# Altium schematic sheet [12] MISC.SchDoc("[12] MISC")
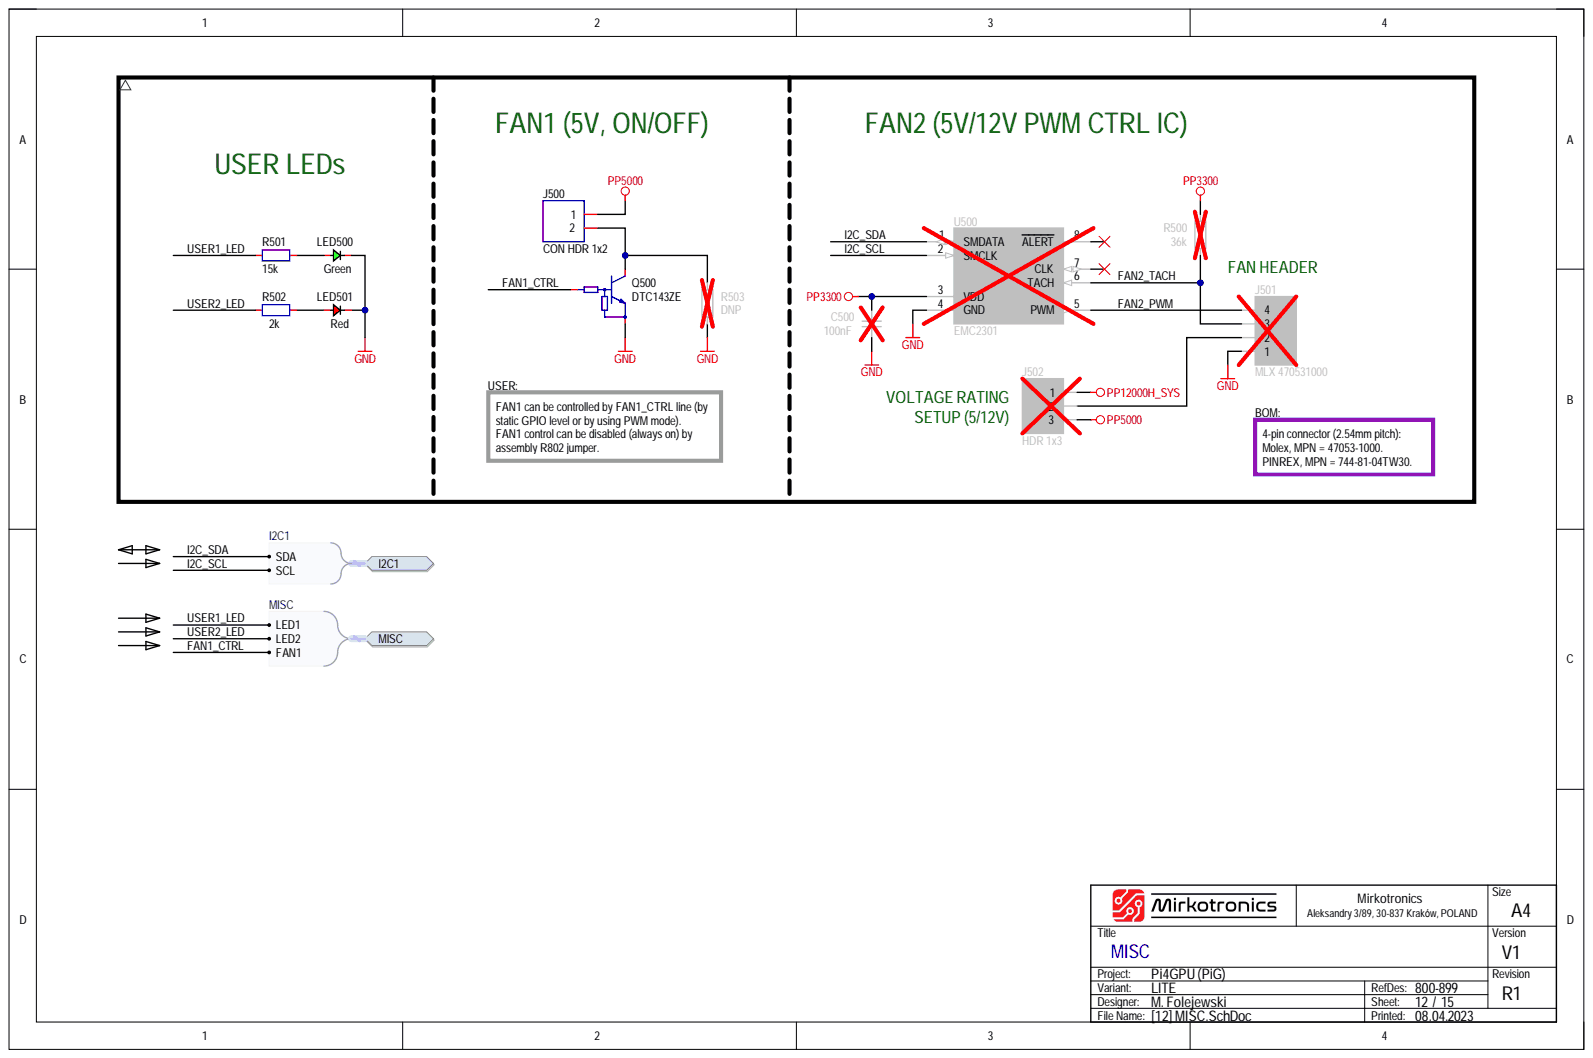
[diagram p0: [12] MISC.SchDoc]

## Parts
C500  100nF  [CAP_0603_R]
J500  CON HDR 1x2  [CON_HDR_P254_1X02_STR]
J501  MLX 470531000  MPN: 470531000  [CON_MLX_470531000]
J502  HDR 1x3  [CON_HDR_P254_1X03_STR_JMP]
LED500  Green  [LED_0805_GRN_R]
LED501  Red  [LED_0805_RED_R]
Q500  DTC143ZE  [PKG_SOT523_SC75_SOT416_P100_R]
R500  36k  [RES_0603_R]
R501  15k  [RES_0603_R]
R502  2k  [RES_0603_R]
R503  DNP  [RES_0603_R]
U500  EMC2301  [ICC_TSSOP8_300X300X110P65]

## Ports
I2C1, I2C1.SCL, I2C1.SDA, MISC, MISC.FAN1, MISC.LED1, MISC.LED2

## Nets
GND: C500.1 J501.1 LED500.1 LED501.1 Q500.2 R503.1 U500.4(GND)
PP3300: C500.2 R500.2 U500.3(VDD)
PP5000: J500.1 J502.3
PP12000H_SYS: J502.1
FAN1_CTRL: Q500.1 ; port MISC
FAN2_PWM: J501.4 U500.5(PWM)
FAN2_TACH: J501.3 R500.1 U500.6(TACH)
I2C_SCL: U500.2(SMCLK) ; port I2C1
I2C_SDA: U500.1(SMDATA) ; port I2C1
USER1_LED: R501.1 ; port MISC
USER2_LED: R502.1 ; port MISC
NetJ500_2: J500.2 Q500.3 R503.2
NetJ501_2: J501.2 J502.2
NetLED500_2: LED500.2 R501.2
NetLED501_2: LED501.2 R502.2

Not connected: U500 7(CLK) 8(ALERT)

## Library data of the parts
C500 = CAP-0008: Capacitor; MLCC; 100nF; TBD; TBD; TBD; TBD; SMD; 0603 (1608);; Database Table Name: CAPACITOR$; Component Kind: Standard; Component Subtype: MLCC; Component Type: Capacitor; Manufacturer: TBD; Mounting Type: SMD; MPN: TBD; Package (Case): 0603 (1608); Supplier: ?; Value: 100nF; Library Name: MirFol_db_local.DbLib
J500 = CON-0020: Connector; Pin Header; 1 x 2 pins; 2.54mm; Male; TBD; TBD; THT; THT;; Manufacturer: GENERIC; Database Table Name: CONNECTOR$; Component Kind: Standard; Component Subtype: Pin Header; Component Type: Connector; Mounting Type: THT; MPN: GENERIC; Package (Case): THT; Supplier: ?; Value: CON HDR 1x2; Library Name: MirFol_db_local.DbLib
J501 = CON_1X04_COL: Connector; 1 Row;; Manufacturer: Molex; Component Subtype: Pin header; Package (Case): THT; Component Kind: Standard; Component Type: Connector; Mounting Type: THT; Value: MLX 470531000; Library Name: MirFol_lib.SchLib
J502 = CON_1X03_COL: Connector; 1 Row;; Manufacturer: GENERIC; Component Subtype: Pin Header; Package (Case): THT; Component Kind: Standard; Component Type: Connector; Mounting Type: THT; MPN: GENERIC; Supplier: ?; Value: HDR 1x3; Library Name: MirFol_lib.SchLib
LED500 = DIO_LED_GRN: Diode; LED; Green;; Manufacturer: Foshan NationStar Optoelectronics; MPN: NCD0805G1; Component Subtype: LED Diode; Package (Case): 0805 (2012); Component Kind: Standard; Component Type: LED; Mounting Type: SMD; Supplier: LCSC; Value: Green; Library Name: MirFol_lib.SchLib
LED501 = DIO_LED_RED: Diode; LED; Red;; Manufacturer: Foshan NationStar Optoelectronics; MPN: NCD0805R1; Component Subtype: LED Diode; Package (Case): 0805 (2012); Component Kind: Standard; Component Type: LED; Mounting Type: SMD; Supplier: LCSC; Value: Red; Library Name: MirFol_lib.SchLib
Q500 = TRA_BJT_NPN_DIG_BEC: Transistor; BJT; NPN; Digital;; Component Subtype: NPN Transistor; Component Kind: Standard; Component Type: Transistor; Mounting Type: SMD; MPN: DTC143ZE; Supplier: ?; Value: DTC143ZE; Library Name: MirFol_lib.SchLib
R500 R503 = RES-0054: Resistor; Thick Film; GENERIC; TBD; TBD; TBD; TBD; TBD; SMD; 0603 (1608);; Database Table Name: RESISTOR$; Component Kind: Standard; Component Subtype: Thick Film; Component Type: Resistor; Manufacturer: GENERIC; Mounting Type: SMD; MPN: GENERIC; Package (Case): 0603 (1608); Supplier: ?; Library Name: MirFol_db_local.DbLib
  R500: Value: 36k
  R503: Value: DNP
R501 = RES-0043: Resistor; Thick Film; 15k; 1%; 100mW; 100PPM; 75V; Standard; SMD; 0603 (1608);; Manufacturer: Yageo Corporation; MPN: TBD; Database Table Name: RESISTOR$; Component Kind: Standard; Component Subtype: Thick Film; Component Type: Resistor; Mounting Type: SMD; Package (Case): 0603 (1608); Supplier: ?; Value: 15k; Library Name: MirFol_db_local.DbLib
R502 = RES-0033: Resistor; Thick Film; 2k2; 1%; 100mW; 100PPM; 75V; Standard; SMD; 0603 (1608);; Manufacturer: Yageo Corporation; MPN: TBD; Database Table Name: RESISTOR$; Component Kind: Standard; Component Subtype: Thick Film; Component Type: Resistor; Mounting Type: SMD; Package (Case): 0603 (1608); Supplier: ?; Value: 2k; Library Name: MirFol_db_local.DbLib
U500 = ICC_MCP_EMC2301_MSOP-8: IC; Fan controller;; Manufacturer: Microchip Technology; MPN: EMC2301-1-ACZL-TR; Package (Case): MSOP-8; Component Kind: Standard; Component Subtype: Fan controller; Component Type: IC; Mounting Type: SMD; Value: EMC2301; Library Name: MirFol_lib.SchLib
